# Altium schematic sheet 60WFLYBACK.SchDoc(60WFLYBACK)
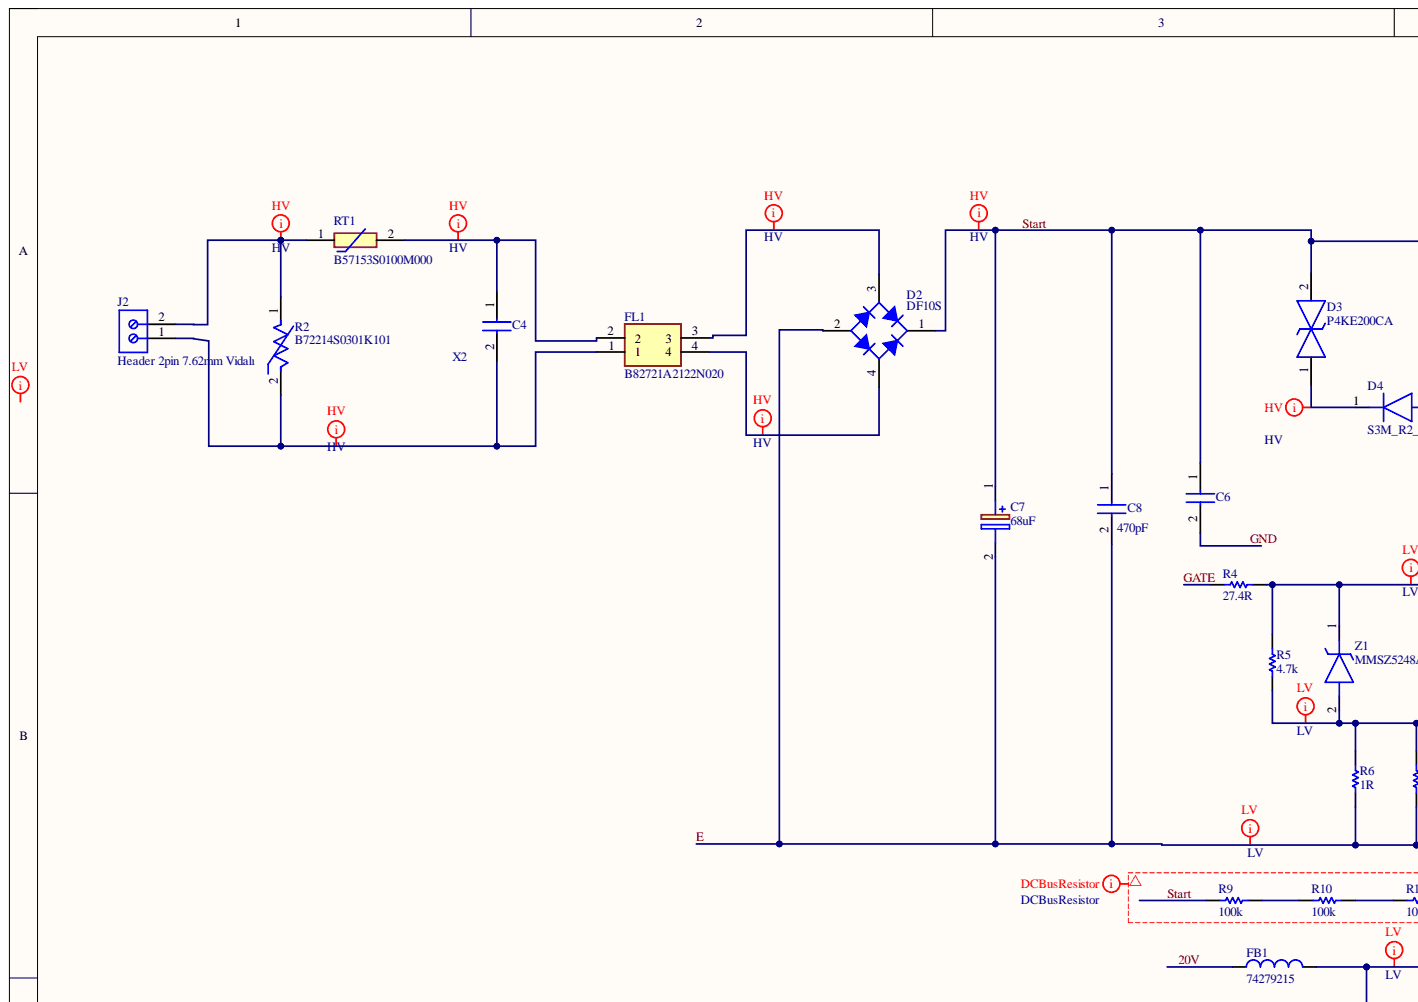
[diagram p0: 60WFLYBACK.SchDoc, top left]
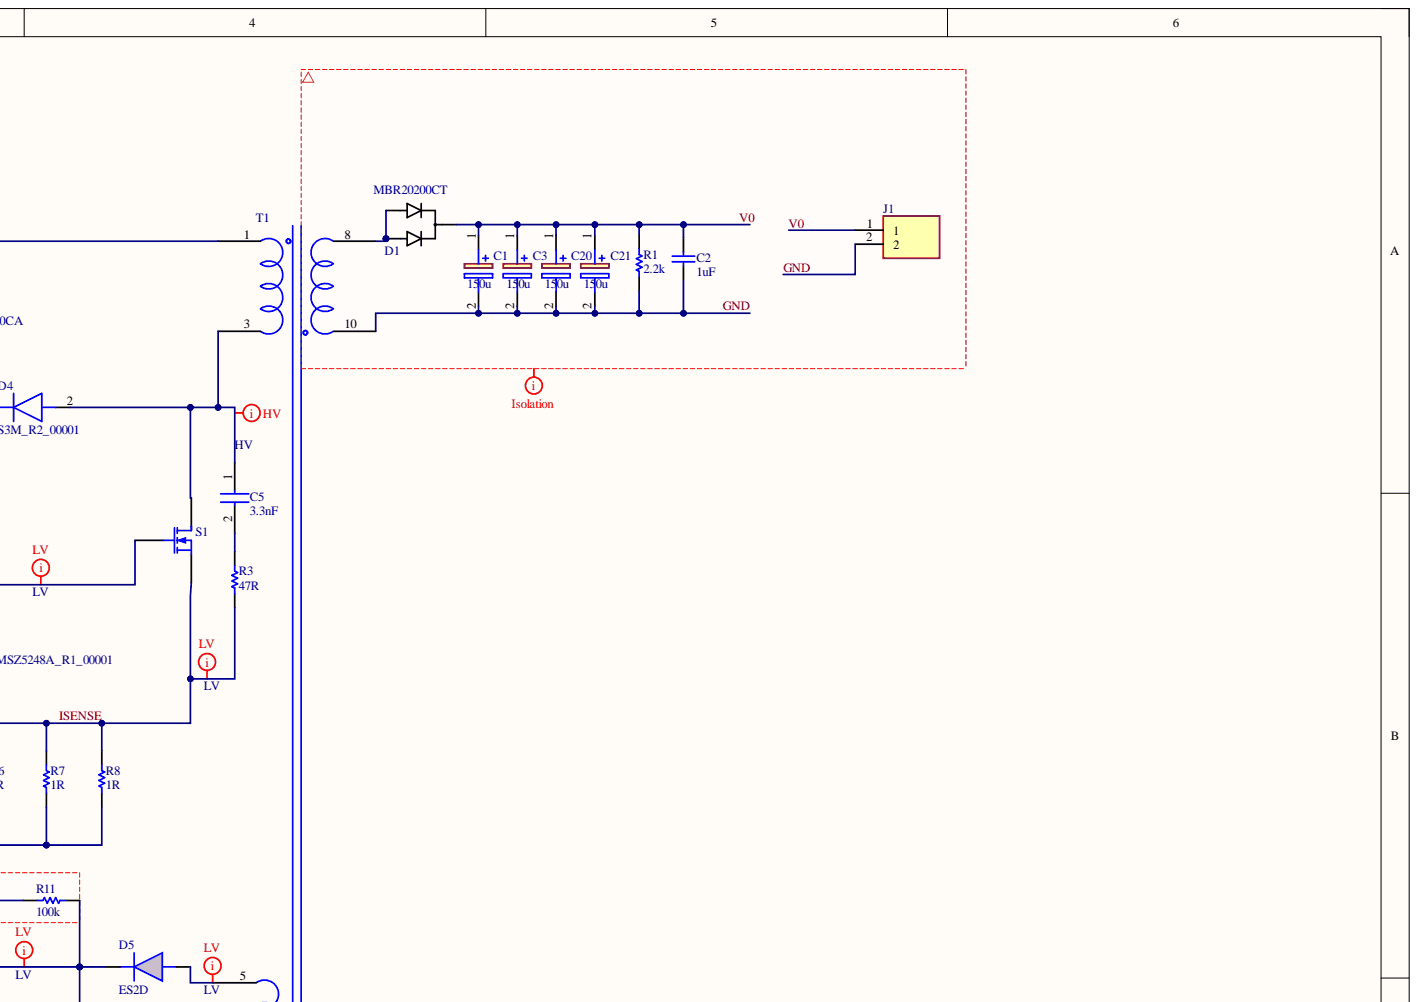
[diagram p1: 60WFLYBACK.SchDoc, top right]
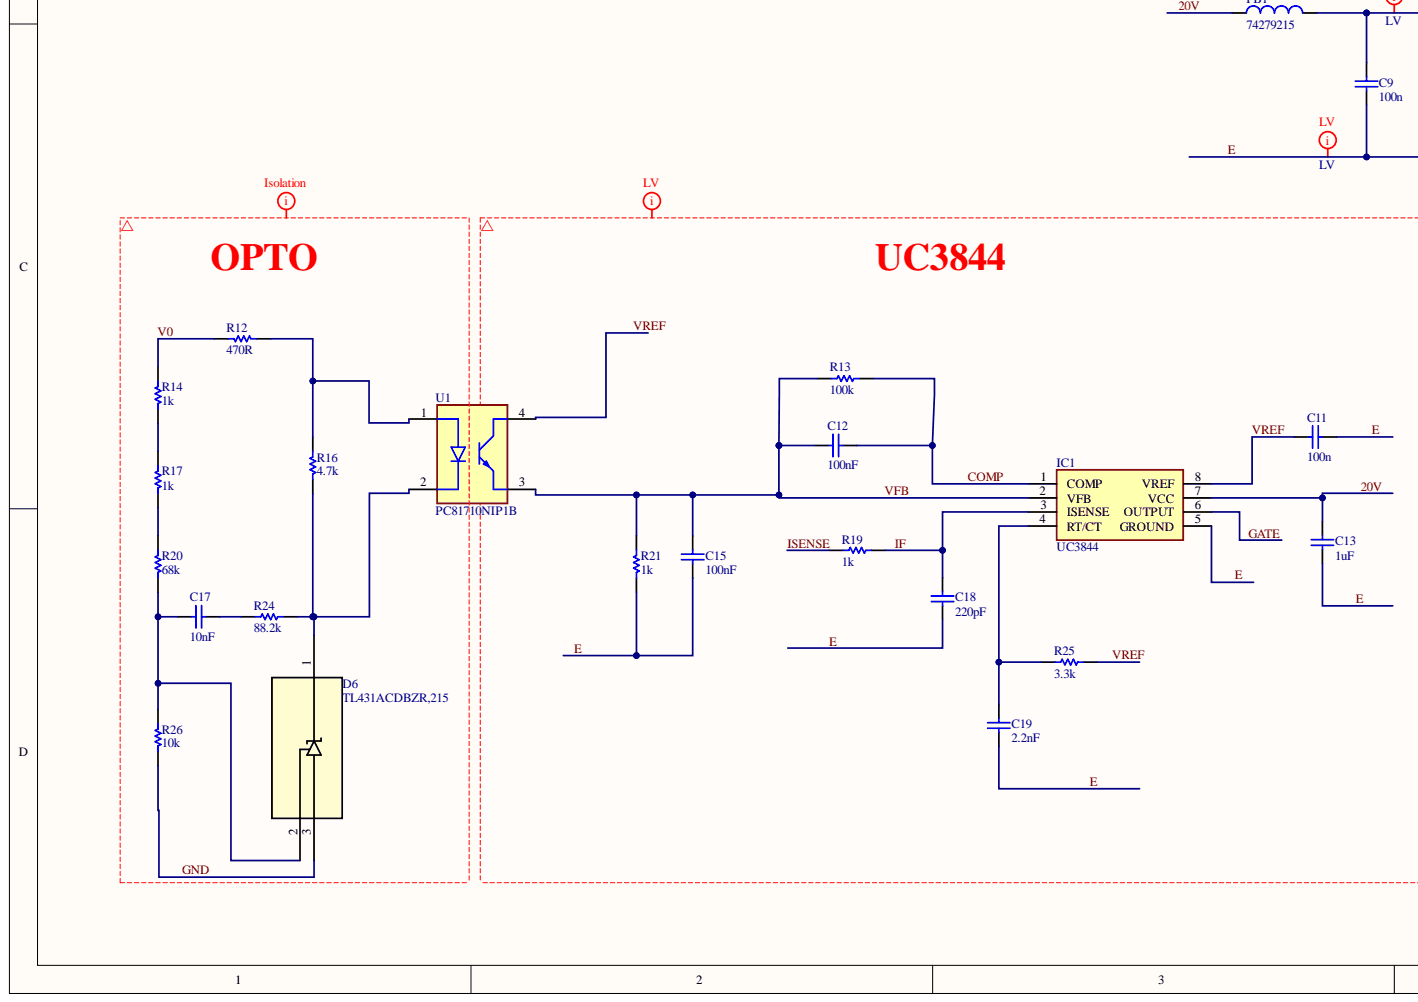
[diagram p2: 60WFLYBACK.SchDoc, bottom left]
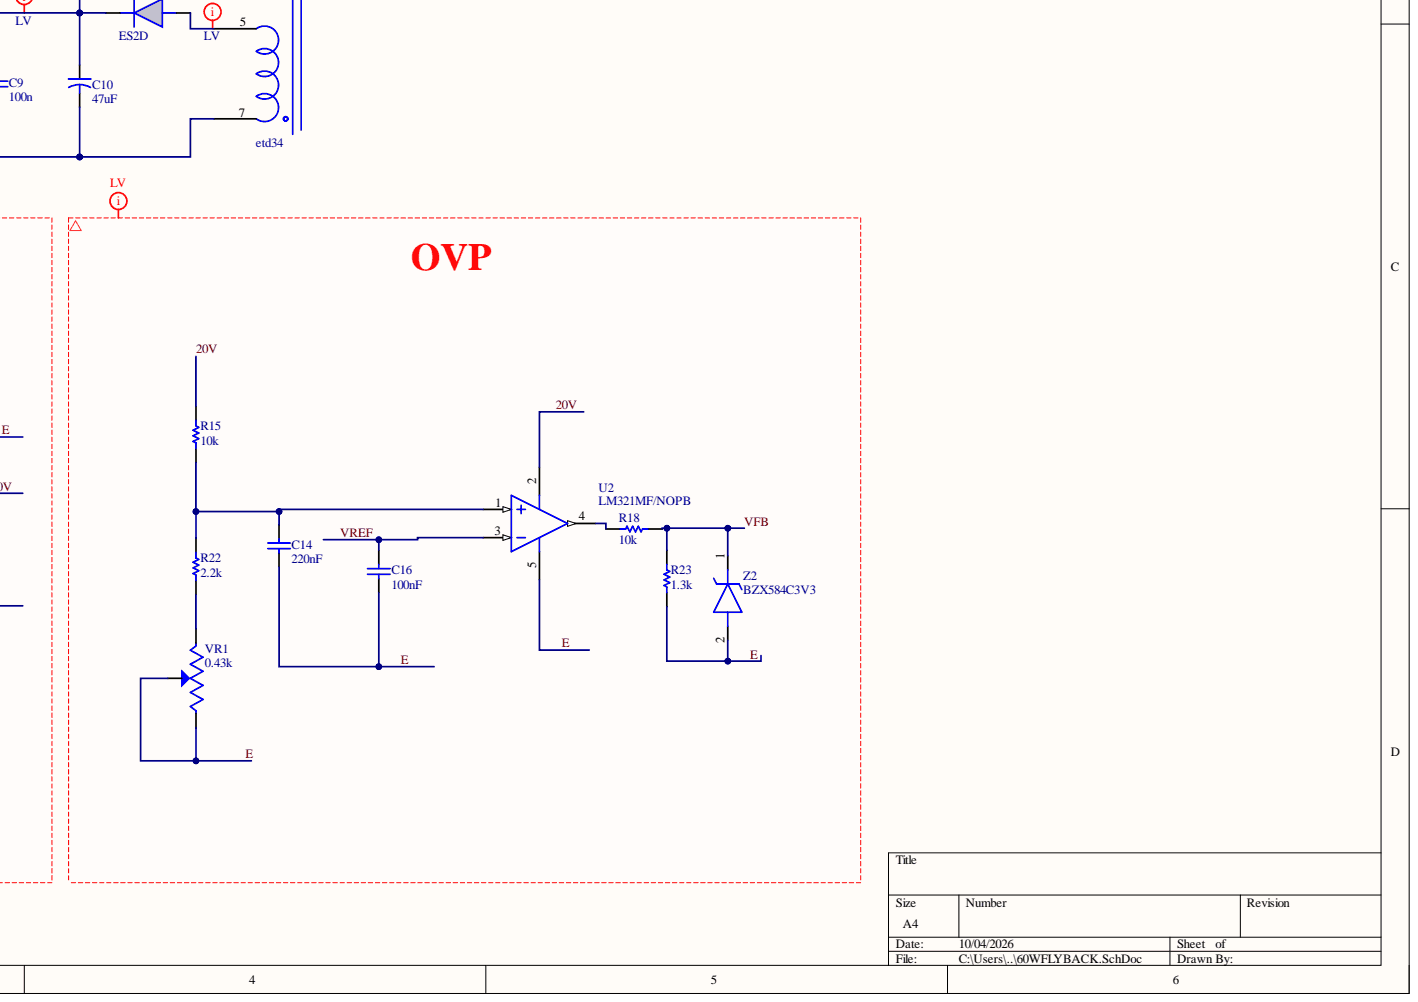
[diagram p3: 60WFLYBACK.SchDoc, bottom right]

## Parts
C1  150u  [CAPPRD500W65D1325H2300]
C2  1uF  [1210 Capacitor]
C3  150u  [CAPPRD500W65D1325H2300]
C4  X2  [B32022_(18_x_5)]
C5  3.3nF  [KB3D332KL518Y]
C6  (no value)  [B32021_(13_x_4)]
C7  68uF  [CAPPRD750W80D1825H2650]
C8  470pF  [C333C471KHR5TA]
C9  100n  [1206]
C10  47uF  [CAPPRD200W50D525H1250]
C11  100n  [1206]
C12  100nF  [1206]
C13  1uF  [1206]
C14  220nF  [1206]
C15  100nF  [1206]
C16  100nF  [1206]
C17  10nF  [1206]
C18  220pF  [1206]
C19  2.2nF  [1206]
C20  150u  [CAPPRD500W65D1325H2300]
C21  150u  [CAPPRD500W65D1325H2300]
D1  MBR20200CT  [THT Diode]
D2  DF10S  [FAIR-SDIP-4_V]
D3  P4KE200CA  [DIOAD1405W86L465D235]
D4  S3M_R2_00001  [DIOM7959X262N]
D5  ES2D  [ES2D]
D6  TL431ACDBZR,215  [SOT23_TO-236AB_NEX]
FB1  74279215  [WE-CBF_1206_L3.2W1.6H1.1_HC]
FL1  B82721A2122N020  [B82721A]
IC1  UC3844  [SOIC127P600X175-8N]
J1  DG126-5.0-02P-14-00AH  [DG1265002P1400AH]  (value not drawn)
J2  Header 2pin 7.62mm Vidalı  Pitch: 7.62mm  [Header 2 Pin 7.62mm Vidalı]
R1  2.2k  [R 1210]
R2  B72214S0301K101  [FP-B72214S0301K101-0]
R3  47R  [THT]
R4  27.4R  [R 1206]
R5  4.7k  [R 1206]
R6  1R  [R 1206]
R7  1R  [R 1206]
R8  1R  [R 1206]
R9  100k  [R 1206]
R10  100k  [R 1206]
R11  100k  [R 1206]
R12  470R  [R 1206]
R13  100k  [R 1206]
R14  1k  [R 1206]
R15  10k  [R 1206]
R16  4.7k  [R 1206]
R17  1k  [R 1206]
R18  10k  [R 1206]
R19  1k  [R 1206]
R20  68k  [R 1206]
R21  1k  [R 1206]
R22  2.2k  [R 1206]
R23  1.3k  [R 1206]
R24  88.2k  [R 1206]
R25  3.3k  [R 1206]
R26  10k  [R 1206]
RT1  B57153S0100M000  [B57153S0***M0**]
S1  PJMB_Mosfet  [PJMB_Mosfet]  (value not drawn)
T1  etd34  [PCBComponent_1]
U1  PC81710NIP1B  [PC817 SMD]
U2  LM321MF/NOPB  [DBV0005A_L]
VR1  0.43k  [3296W1503LF]
Z1  MMSZ5248A_R1_00001  [MMSZ5248A_R1_00001]
Z2  BZX584C3V3  [SODFL1608X65N]

## Nets
20V: C13.2 FB1.1 IC1.7(VCC) R15.2 U2.2
COMP: C12.2 IC1.1(COMP) R13.2
E: C7.2 C8.2 C9.1 C10.2 C11.2 C13.1 C14.1 C15.1 C16.1 C18.1 C19.1 D2.2 IC1.5(GROUND) R6.1 R7.1 R8.1 R21.1 R23.1 T1.7 U2.5 VR1.1 VR1.2 Z2.2
GATE: IC1.6(OUTPUT) R4.1
GND: C1.2 C2.1 C3.2 C6.2 C20.2 C21.2 D6.3 J1.2 R1.1 R26.1 T1.10
IF: C18.2 IC1.3(ISENSE) R19.2
ISENSE: R3.1 R5.1 R6.2 R7.2 R8.2 R19.1 S1.3 Z1.2
Start: C6.1 C7.1 C8.1 D2.1 D3.2 R9.1 T1.1
V0: C1.1 C2.2 C3.1 C20.1 C21.1 D1.2 J1.1 R1.2 R12.2 R14.2
VFB: C12.1 C15.2 IC1.2(VFB) R13.1 R18.2 R21.2 R23.2 U1.3 Z2.1
VREF: C11.1 C16.2 IC1.8(VREF) R25.2 U1.4 U2.3
NetC4_1: C4.1 FL1.2 RT1.2
NetC4_2: C4.2 FL1.1 J2.1 R2.2
NetC5_1: C5.1 D4.2 S1.2 T1.3
NetC5_2: C5.2 R3.2
NetC9_2: C9.2 C10.1 D5.1 FB1.2 R11.2
NetC14_2: C14.2 R15.1 R22.2 U2.1
NetC17_1: C17.1 D6.2 R20.1 R26.2
NetC17_2: C17.2 R24.1
NetC19_2: C19.2 IC1.4(RT/CT) R25.1
NetD1_1: D1.1 D1.3 T1.8
NetD2_3: D2.3 FL1.3
NetD2_4: D2.4 FL1.4
NetD3_1: D3.1 D4.1
NetD5_2: D5.2 T1.5
NetD6_1: D6.1 R16.1 R24.2 U1.2
NetJ2_2: J2.2 R2.1 RT1.1
NetR4_2: R4.2 R5.2 S1.1 Z1.1
NetR9_2: R9.2 R10.1
NetR10_2: R10.2 R11.1
NetR12_1: R12.1 R16.2 U1.1
NetR14_1: R14.1 R17.2
NetR17_1: R17.1 R20.2
NetR18_1: R18.1 U2.4
NetR22_1: R22.1 VR1.3

## Library data of the parts
C1 C3 C20 C21 = EXR101M2AB: Capacitor Polarised; Manufacturer_Name: Hitano; Component Kind: Standard; Datasheet Link: https://www.hitano.com.tw/wp-content/uploads/doc/EXR_20231013.pdf; Height: 23mm; Manufacturer_Part_Number: EXR101M2AB
C2 = 1210 Capacitor: Component Kind: Standard; Description: 1210 Capacitor; Library Name: recep.SchLib
C4 = B32022A3103K189: X2 Capacitor; Manufacturer_Name: TDK; Component Kind: Standard; Datasheet Link: https://product.tdk.com/system/files/dam/doc/product/capacitor/film/emi/data_sheet/20/20/db/fc_2009/y2_b32021_026.pdf; Manufacturer_Part_Number: B32022A3103K189; Mouser Part Number: 871-B32022A3103K189; Library Name: recep.SchLib
C5 = KB3D332KL518Y: Manufacturer_Name: Cinetech; Component Kind: Standard; Datasheet Link: https://cdn.ozdisan.com/ETicaret_Dosya/401993_4423979.PDF; Description: KB3D332KL518Y; Height: 13.5mm; Library Name: SamacSys.SchLib; Manufacturer_Part_Number: KB3D332KL518Y
C6 = B32021A3222M000: Capacitor Y2; Manufacturer_Name: TDK; Arrow Part Number: B32021A3222M000; Arrow Price/Stock: https://www.arrow.com/en/products/b32021a3222m000/epcos-tdk; Component Kind: Standard; Datasheet Link: https://product.tdk.com/system/files/dam/doc/product/capacitor/film/emi/data_sheet/20/20/db/fc_2009/y2_b32021_026.pdf; Manufacturer_Part_Number: B32021A3222M000
C7 = EKXG401ELL680MM25S: CAP.EL.68uF 400V 16x30 7.5MM 10% 105C L.ESR BLK; Manufacturer_Name: Chemi-Con; Component Kind: Standard; Datasheet Link: https://datasheet.datasheetarchive.com/originals/distributors/Datasheets-DGA21/2010944.pdf; Height: 26.5mm; Manufacturer_Part_Number: EKXG401ELL680MM25S; Mouser Part Number: 661-EKXG401ELL680; Mouser Price/Stock: https://www.mouser.com/Search/Refine.aspx?Keyword=661-EKXG401ELL680
C8 = C333C471KHR5TA: CAP.CER 470pF 3000V 10% X7R RADIAL BULK; Manufacturer_Name: KEMET; Arrow Part Number: C333C471KHR5TA; Arrow Price/Stock: https://www.arrow.com/en/products/c333c471khr5ta/kemet-corporation?region=europe; Component Kind: Standard; Datasheet Link: https://search.kemet.com/component-documentation/download/specsheet/C333C471KHR5TA; Height: 10.67mm; Manufacturer_Part_Number: C333C471KHR5TA
C9 C11-C19 = C 1206: SMD 1206; Component Kind: Standard; Library Name: recep.SchLib
C10 = C 47uF 25V 250mArms 400mOhm: 1189-1590-1-ND; Component Kind: Standard; ComponentLink1Description: http://www.rubycon.co.jp/en/catalog/e_pdfs/aluminum/e_px.pdf; Library Name: FlybackKutuphane.IntLib
D1 = THT Diode: Component Kind: Standard; Library Name: recep.SchLib
D2 = CMP-2000-06153-1: Bridge Rectifier, 1.5 A, 1000 V, 4-Pin SDIP, RoHS, Tape and Reel; Manufacturer: FairchildSemiconductor; Bridge Type: SinglePhase; Configuration: Single; Peak Average Forward Current: 1.5@Ta=40CA; Peak Forward Voltage: 1.1@1AV; Peak Reverse Current: 5uA; Peak Reverse Repetitive Voltage: 1000V; Category: BridgeRectifier; Component Kind: Standard; Datasheet URL: http://www.farnell.com/datasheets/1941063.pdf; Datasheet Version: Rev. 1.5, 05/2015; Library Name: Altium Content Vault; Manufacturer URL: https://www.fairchildsemi.com/; Mounting: SurfaceMount; Mounting Technology: SurfaceMount; Operating Temperature: -55to150°C; Package: 4SDIPSMD; Package Description: 4-Pin Surface Mount Device, Body 8.28 x 6.35 mm, Pitch 5.1 mm; Package Reference: SDIP 4L; Packaging: Tape&Reel
D3 = P4KE200CA: TVS Diode (Bi-directional); Manufacturer_Name: PANJIT; Component Kind: Standard; Datasheet Link: https://www.panjit.com.tw/en/Product/downloadPDF/P4KE200CA; Library Name: SamacSys.SchLib; Manufacturer_Part_Number: P4KE200CA
D4 = S3M_R2_00001: Diode; Manufacturer_Name: PANJIT; Component Kind: Standard; Datasheet Link: https://cdn.ozdisan.com/ETicaret_Dosya/584140_3070204.pdf; Height: 2.62mm; Manufacturer_Part_Number: S3M_R2_00001
D6 = TL431ACDBZR,215: No Description Available; Mfr_Name: Nexperia; Component Kind: Standard; RefDes: RefDes; Type: Type; Library Name: recep.SchLib
FB1 = CMP-0220-00013-2: FERRITE BEAD 1206 80R 3A; NexarPartId: 8917004; DC Resistance (DCR): 30mΩ; Impedance: 80Ω; Number of Lines: 1; Test Frequency: 100MHz; Tolerance: 25%; Case Code (Imperial): 1206; Case Code (Metric): 3216; Case/Package: SMD/SMT; Component Kind: Standard; Current Rating: 3A; Depth: 1.6mm; Height: 1.1mm; Lead Free: Lead Free; Length: 3.2mm; Library Name: Altium Content Vault; Max Current Rating: 3A; Max DC Current: 3A; Max Operating Temperature: 125°C; Min Operating Temperature: -55°C; Mount: Surface Mount; Packaging: Cut Tape (CT); REACH SVHC: Unknown; Resistance: 30mΩ; RoHS: Compliant; Termination: SMD/SMT; Weight: 28.009329mg; Width: 1.6mm
FL1 = B82721A2122N020: COMMON MODE CHOKE 6.8mH 1.2A 2LN; Manufacturer_Name: TDK; Arrow Part Number: B82721A2122N020; Arrow Price/Stock: https://www.arrow.com/en/products/b82721a2122n020/tdk?region=europe; Component Kind: Standard; Datasheet Link: https://product.tdk.com/system/files/dam/doc/product/emc/emc/line-filter/data_sheet/30/db/ind_2008/b82721a_j_k.pdf; Height: 12.6mm; Library Name: SamacSys.SchLib; Manufacturer_Part_Number: B82721A2122N020
IC1 = UC3844D8: Integrated Circuit; Manufacturer_Name: Texas Instruments; Arrow Part Number: UC3844D8; Arrow Price/Stock: https://www.arrow.com/en/products/uc3844d8/texas-instruments; Component Kind: Standard; Datasheet Link: http://www.ti.com/lit/gpn/uc3844; Height: 1.75mm; Library Name: SamacSys.SchLib; Manufacturer_Part_Number: UC3844D8; Mouser Part Number: 595-UC3844D8; Mouser Price/Stock: https://www.mouser.co.uk/ProductDetail/Texas-Instruments/UC3844D8?qs=j%2FZo4ajzVJI0cAZEiVaYjQ%3D%3D
J1 = DG126-5.0-02P-14-00AH: Connector; Manufacturer_Name: Degson; Component Kind: Standard; Datasheet Link: https://asset.conrad.com/media10/add/160267/c1/-/en/001327203DS01/datasheet-1327203-degson-dg126-50-02p-14-00ah-1-screw-terminal-2-mm-number-of-pins-2-green-1-pcs.pdf; Height: 10.4mm; Library Name: SamacSys.SchLib; Manufacturer_Part_Number: DG126-5.0-02P-14-00AH
J2 = Header 2pin 7.62mm Vidalı: 691214410002; Part Number: 691218410002; Compliance: Lead free / RoHS; Modularity: Yes; PCB/Cable/Panel: PCB; Pin Number: 2; Rated Current [UL]: 30A; Working Voltage [UL]: 300VAC; Application: Rising Cage Clamp; Component Kind: Standard; ComponentLink1Description: Manufacturer; ComponentLink1URL: http://www.we-online.de; ComponentLink2Description: Datasheet; ComponentLink2URL: https://katalog.we-online.de/em/datasheet/691218410002.pdf; Mounting Technology: THT; Operating Temperature: -40 to +105degC; Packaging: Box; Published Date: October 2019; Type: Horizontal; Library Name: FlybackKutuphane.IntLib; Publisher: Wurth Elektronik
R1 = R 1210: Component Kind: Standard; Description: R 1210; Library Name: recep.SchLib
R2 = CMP-10618-000016-1: VARISTOR 470V 4.5KA DISC 14MM; Capacitance: 300pF; Clamping Current: 50A; Clamping Voltage: 775V; Energy: 76J; Lead Length: 30mm; Lead/Base Style: Radial; Max Voltage Rating (AC): 300V; Max Voltage Rating (DC): 385V; Tolerance: 10%; Component Kind: Standard; Depth: 5.2mm; Diameter: 14mm; Lead Pitch: 7.5mm; Library Name: Altium Content Vault; Max Operating Temperature: 85°C; Max Surge Current: 4.5kA; Min Operating Temperature: -40°C; Mount: Radial; Package Quantity: 500; Packaging: Bulk; REACH SVHC: No SVHC; RoHS Compliant: Yes; Series: StandardD; Thickness: 5.2mm; Varistor Voltage: 470V; Voltage Rating (AC): 300V; Voltage Rating (DC): 385V; Voltage Tolerance: 10%; Weight: 0.141096oz
R3 = THT Resistor: Component Kind: Standard; Library Name: recep.SchLib
R4-R26 = R 1206: SMD 1206  RESISTOR; Component Kind: Standard; Library Name: recep.SchLib
RT1 = B57153S0100M000: THERMISTOR NTC 10R 8.5MM 2A BULK EPCOS; Manufacturer_Name: TDK; Arrow Part Number: B57153S0100M000; Arrow Price/Stock: https://www.arrow.com/en/products/b57153s0100m000/tdk?region=europe; Component Kind: Standard; Datasheet Link: https://product.tdk.com/system/files/dam/doc/product/protection/current/ntc-limiter/data_sheet/50/db/icl_16/s153.pdf; Height: 13mm; Manufacturer_Part_Number: B57153S0100M000; Mouser Part Number: 871-B57153S0100M000; Mouser Price/Stock: https://www.mouser.co.uk/ProductDetail/EPCOS-TDK/B57153S0100M000?qs=AKDv8POSxR22bWjVdDPC%2Fw%3D%3D
U1 = PC817: Optocoupler; Manufacturer_Name: Sharp Microelectronics; Component Kind: Standard; Manufacturer_Part_Number: PC81710NIP1B; Library Name: recep.SchLib
U2 = CMP-0055-01346-3: IC-321 OP.AMP.QUAD SOT23-5 TI; Manufacturer: Texas Instruments; CompType: Amplifiers; PackageTopMarking: A63A*XTT; PowerWise: N; Component Kind: Standard; ComponentLink1Description: Datasheet URL; ComponentLink1URL: http://www.ti.com/general/docs/lit/getliterature.tsp?genericPartNumber=LM321&fileType=pdf; Library Name: Altium Content Vault; Package Reference: DBV0005A; PackageDescription: Molded SOT-23, .115 x .063 x .040 in Body, 5 Lead, .0375 in Pitch; PinCount: 5; RoHS: Y
VR1 = 3296W-1-102: TRIMPOT CERMET MULTI TURN VER.ADJ 2K 0.5W; Manufacturer_Name: Bourns; Arrow Part Number: 3296W-1-102; Arrow Price/Stock: https://www.arrow.com/en/products/3296w-1-102/bourns?region=nac; Component Kind: Standard; Datasheet Link: https://www.bourns.com/pdfs/3296.pdf; Height: 10.28mm; Library Name: SamacSys.SchLib; Manufacturer_Part_Number: 3296W-1-102; Mouser Part Number: 652-3296W-1-102; Mouser Price/Stock: https://www.mouser.co.uk/ProductDetail/Bourns/3296W-1-102?qs=fd0Ij%252BN8svybmCDBgax2Vg%3D%3D
Z1 = MMSZ5248A_R1_00001: DIODE ZENER 500mW 18V ±2% SOD123 SMT; Manufacturer_Name: PANJIT; Component Kind: Standard; Datasheet Link: https://www.panjit.com.tw/upload/datasheet/MMSZ5229A_SERIES.pdf; Height: 1.35mm; Library Name: SamacSys.SchLib; Manufacturer_Part_Number: MMSZ5248A_R1_00001
Z2 = BZX584C3V3: Zener Diode 3.3V; Manufacturer_Name: PANJIT; Component Kind: Standard; Datasheet Link: https://www.panjit.com.tw/en/Product/downloadPDF/BZX584C3V3; Height: 0.65mm; Manufacturer_Part_Number: BZX584C3V3
D5 = ES2D: Component Kind: Standard; Library Name: SamacSys.SchLib
S1 = PJMB_Mosfet: Component Kind: Standard; Library Name: recep.SchLib
T1 = etd34: Component Kind: Standard; Library Name: SamacSys.SchLib
